# Altium schematic sheet Mataric1.SchDoc(Mataric1)
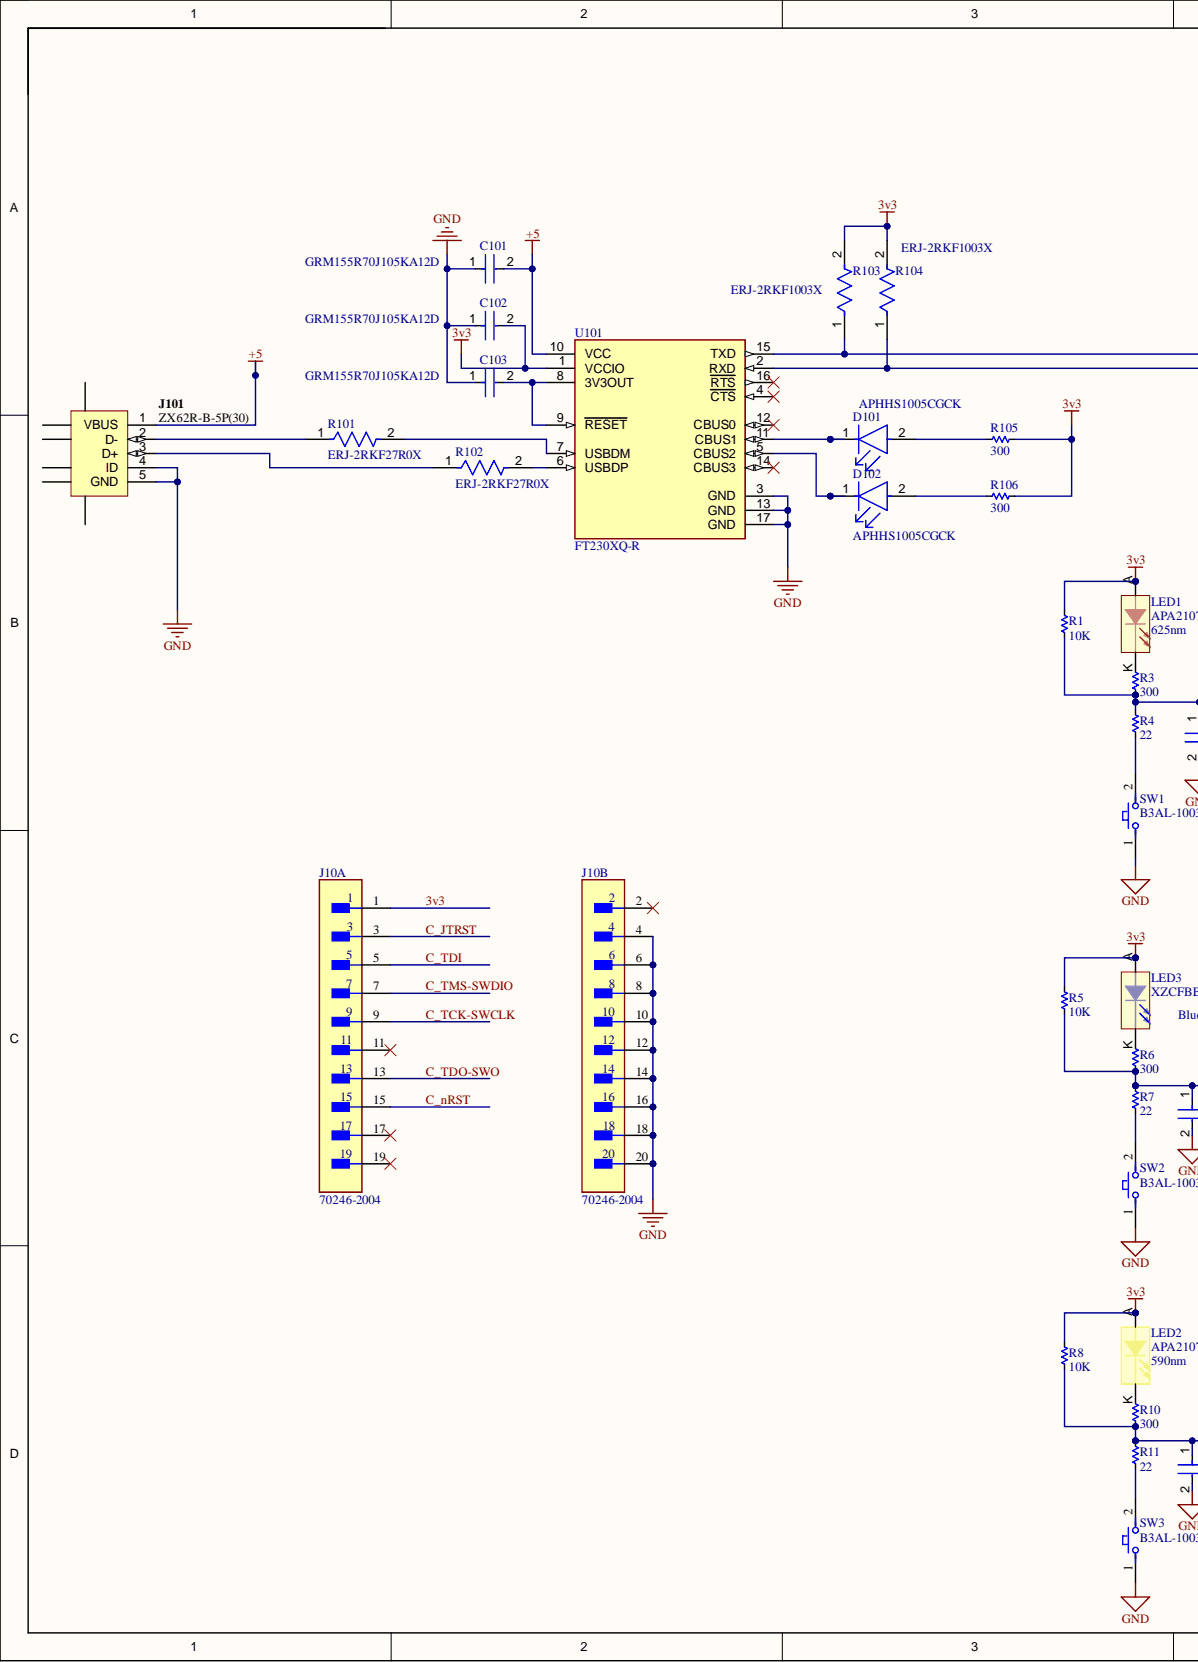
[diagram p0: Mataric1.SchDoc, left]
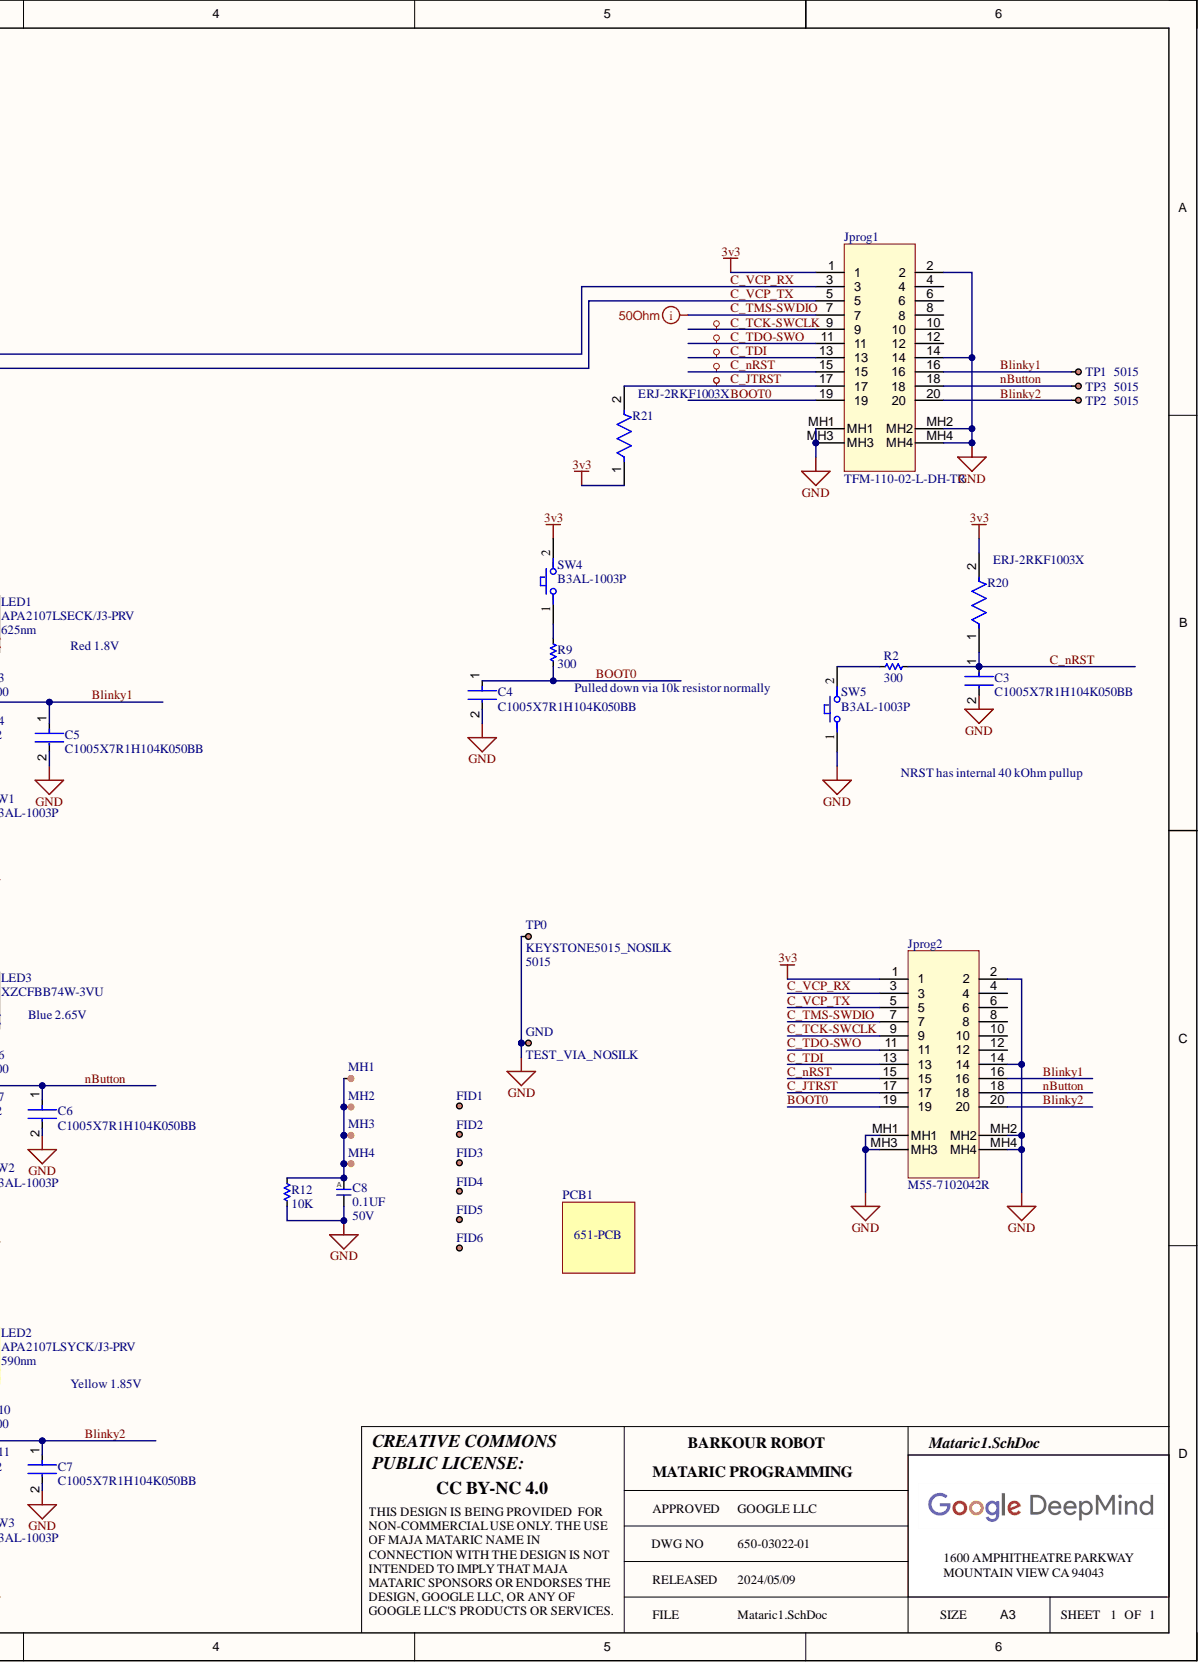
[diagram p1: Mataric1.SchDoc, right]

## Parts
C3  C1005X7R1H104K050BB  [FP-C1005-050-0_05-IPC_C]
C4  C1005X7R1H104K050BB  [FP-C1005-050-0_05-IPC_C]
C5  C1005X7R1H104K050BB  [FP-C1005-050-0_05-IPC_C]
C6  C1005X7R1H104K050BB  [FP-C1005-050-0_05-IPC_C]
C7  C1005X7R1H104K050BB  [FP-C1005-050-0_05-IPC_C]
C8  value 0.1UF  Voltage: 50V  [C0402]  (hidden comment: __Template_Cap_Auto)
C101  GRM155R70J105KA12D  [FP-GRM155-0_05-MFG]
C102  GRM155R70J105KA12D  [FP-GRM155-0_05-MFG]
C103  GRM155R70J105KA12D  [FP-GRM155-0_05-MFG]
D101  APHHS1005CGCK  [FP-APHHS1005CGCK-MFG]
D102  APHHS1005CGCK  [FP-APHHS1005CGCK-MFG]
FID1  FIDUCIAL  [FIDUCIAL_1MM]  (value not drawn)  (no BOM)
FID2  FIDUCIAL  [FIDUCIAL_1MM]  (value not drawn)  (no BOM)
FID3  FIDUCIAL  [FIDUCIAL_1MM]  (value not drawn)  (no BOM)
FID4  FIDUCIAL  [FIDUCIAL_1MM]  (value not drawn)  (no BOM)
FID5  FIDUCIAL  [FIDUCIAL_1MM]  (value not drawn)  (no BOM)
FID6  FIDUCIAL  [FIDUCIAL_1MM]  (value not drawn)  (no BOM)
GND  TEST_VIA_NOSILK  [TP_VIA_31pad_15drill_NS]  (no BOM)
J10  70246-2004  [FP-70246-2004-MFG]  (parts A,B)
J101  ZX62R-B-5P(30)  [HIRO-ZX62R-B-5P_V]
Jprog1  MFG P/N: TFM-110-02-L-DH-TR  [SAMTEC_TFM-110-02-L-DH]
Jprog2  M55-7102042R  [CON_M55-7102042R]
LED1  value APA2107LSECK/J3-PRV  Color / Wavelength: 625nm  MFG P/N: APA2107LSECK/J3-PRV  [LED_APA2106CGCK]  (hidden comment: __Template_LED_RED_AK)
LED2  value APA2107LSYCK/J3-PRV  Color / Wavelength: 590nm  MFG P/N: APA2107LSYCK/J3-PRV  [LED_APA2106CGCK]  (hidden comment: __Template_LED_YELLOW_AK)
LED3  value XZCFBB74W-3VU  MFG P/N: XZCFBB74W-3VU  [LED_APA2106CGCK]  (hidden comment: __Template_LED_BLUE_AK)
MH1  MH  [MH_1.6MM]  (no BOM)
MH2  MH  [MH_1.6MM]  (no BOM)
MH3  MH  [MH_1.6MM]  (no BOM)
MH4  MH  [MH_1.6MM]  (no BOM)
PCB1  PCB  (mechanical)
R1  value 10K  [R0805]
R2  value 300  [R0603]
R3  value 300  [R0603]
R4  value 22  [R0805]
R5  value 10K  [R0805]
R6  value 300  [R0603]
R7  value 22  [R0805]
R8  value 10K  [R0805]
R9  value 300  [R0603]
R10  value 300  [R0603]
R11  value 22  [R0805]
R12  value 10K  [R0805]
R20  ERJ-2RKF1003X  [FP-ERJ2RK-IPC_C]
R21  ERJ-2RKF1003X  [FP-ERJ2RK-IPC_C]
R101  ERJ-2RKF27R0X  [FP-ERJ2R-IPC_B]
R102  ERJ-2RKF27R0X  [FP-ERJ2R-IPC_B]
R103  ERJ-2RKF1003X  [FP-ERJ2RK-IPC_C]
R104  ERJ-2RKF1003X  [FP-ERJ2RK-IPC_C]
R105  value 300  [R0603]
R106  value 300  [R0603]
SW1  B3AL-1003P  [FP-B3AL-1003P-MFG]
SW2  B3AL-1003P  [FP-B3AL-1003P-MFG]
SW3  B3AL-1003P  [FP-B3AL-1003P-MFG]
SW4  B3AL-1003P  [FP-B3AL-1003P-MFG]
SW5  B3AL-1003P  [FP-B3AL-1003P-MFG]
TP0  KEYSTONE5015_NOSILK  MFG P/N: 5015  [KEYSTONE_5015_NS]
TP1  KEYSTONE5015_NOSILK  MFG P/N: 5015  [KEYSTONE_5015_NS]
TP2  KEYSTONE5015_NOSILK  MFG P/N: 5015  [KEYSTONE_5015_NS]
TP3  KEYSTONE5015_NOSILK  MFG P/N: 5015  [KEYSTONE_5015_NS]
U101  FT230XQ-R  [FP-QFN-16-MFG]

## Nets
+5: C101.2 J101.1(VBUS) U101.10(VCC)
GND: C3.2 C4.2 C5.2 C6.2 C7.2 C8.2 C101.1 C102.1 C103.1 GND.1 J10.4 J10.6 J10.8 J10.10 J10.12 J10.14 J10.16 J10.18 J10.20 J101.4(ID) J101.5(GND) Jprog1.2 Jprog1.14 Jprog1.MH1 Jprog1.MH2 Jprog1.MH3 Jprog1.MH4 Jprog2.2 Jprog2.14 Jprog2.MH1 Jprog2.MH2 Jprog2.MH3 Jprog2.MH4 R12.1 SW1.1 SW2.1 SW3.1 SW5.1 TP0.1 U101.3(GND) U101.13(GND) U101.17(GND)
3v3: C102.2 J10.1 Jprog1.1 Jprog2.1 LED1.A LED2.A LED3.A R1.2 R5.2 R8.2 R20.2 R21.1 R103.2 R104.2 R105.1 R106.1 SW4.2 U101.1(VCCIO)
Blinky1: C5.1 Jprog1.16 Jprog2.16 R1.1 R3.1 R4.2 TP1.1
Blinky2: C7.1 Jprog1.20 Jprog2.20 R8.1 R10.1 R11.2 TP2.1
BOOT0: C4.1 Jprog1.19 Jprog2.19 R9.1
C_JTRST: J10.3 Jprog1.17 Jprog2.17 R21.2
C_nRST: C3.1 J10.15 Jprog1.15 Jprog2.15 R2.1 R20.1
C_TCK-SWCLK: J10.9 Jprog1.9 Jprog2.9
C_TDI: J10.5 Jprog1.13 Jprog2.13
C_TDO-SWO: J10.13 Jprog1.11 Jprog2.11
C_TMS-SWDIO: J10.7 Jprog1.7 Jprog2.7
C_VCP_RX: Jprog1.3 Jprog2.3 R103.1 U101.15(TXD)
C_VCP_TX: Jprog1.5 Jprog2.5 R104.1 U101.2(RXD)
nButton: C6.1 Jprog1.18 Jprog2.18 R5.1 R6.1 R7.2 TP3.1
NetC8_1: C8.1 MH1.1 MH2.1 MH3.1 MH4.1 R12.2
NetC103_2: C103.2 U101.8(3V3OUT) U101.9(RESET)
NetD101_1: D101.1 U101.11(CBUS1)
NetD101_2: D101.2 R105.2
NetD102_1: D102.1 U101.5(CBUS2)
NetD102_2: D102.2 R106.2
NetJ101_2: J101.2(D-) R101.1
NetJ101_3: J101.3(D+) R102.1
NetLED1_K: LED1.K R3.2
NetLED2_K: LED2.K R10.2
NetLED3_K: LED3.K R6.2
NetR2_2: R2.2 SW5.2
NetR4_1: R4.1 SW1.2
NetR7_1: R7.1 SW2.2
NetR9_2: R9.2 SW4.1
NetR11_1: R11.1 SW3.2
NetR101_2: R101.2 U101.7(USBDM)
NetR102_2: R102.2 U101.6(USBDP)

Not connected: J10 2 11 17 19; J101 6 7 8 9 10 11; Jprog1 4 6 8 10 12; Jprog2 4 6 8 10 12; U101 4(CTS) 12(CBUS0) 14(CBUS3) 16(RTS)

## Library data of the parts
C3-C7 = CMP-2000-05393-2: Multilayer Ceramic Capacitors 100nF ±10% 50V X7R SMD 0402; Capacitance: 100nF; Case Code (Imperial): 0402; Case Code (Metric): 1005; Case/Package: 0402; Component Kind: Standard; Construction: Flat; Depth: 0.5mm; Dielectric: X7R; Dielectric Material: Ceramic; Height: 0.5mm; Lead Free: Lead Free; Length: 1mm; Library Name: Altium Content Vault; Max Operating Temperature: 125°C; Min Operating Temperature: -55°C; Mount: Surface Mount; Package Quantity: 1; Packaging: Tape and Reel; Radiation Hardening: No; REACH SVHC: No SVHC; Resistor Type: Ceramic; RoHS Compliant: Yes; Series: C; Termination: SMD/SMT; Thickness: 0.022inch; Tolerance: 10%; Voltage: 50V; Voltage Rating: 50V; Voltage Rating (DC): 50V; Weight: 2.3E-05oz; Width: 0.02inch
C8 = 951: CAP, CER, X7R, 0.1uF, 50V, 10%, 0402, AUTO GRADE; COMMON: 150-00281-00; Database Table Name: Capacitors; EES: 150-04463-00; MFG: TDK; MFG P/N: CGA2B3X7R1H104K050BB; PROXY: Use ID #1723; Component Kind: Standard; ComponentLink1Description: Datasheet; Dielectric: X7R; Gecko: 150-00281-00; Height: 0.56mm T; ID: 951; Library Name: AltiumReleaseLibDB.DBLib; LOON: 150-00281-00; Package: 0402; Status: Released; Tolerance: 10%; XPN: 150-00281-00
C101-C103 = CMP-2008-04587-2: Chip Multilayer Ceramic Capacitors for General Purpose, 0402, 1.0uF, X7R, 15%, 10%, 6.3V; Capacitance: 1uF; Case Code (Imperial): 0402; Case Code (Metric): 1005; Case/Package: 0402; Component Kind: Standard; Depth: 0.5mm; Dielectric: X7R; Height: 0.5mm; Lead Free: Lead Free; Length: 1mm; Library Name: Altium Content Vault; Material: Ceramic; Max Operating Temperature: 125°C; Min Operating Temperature: -55°C; Mount: Surface Mount; Package Quantity: 10000; Packaging: Tape and Reel; Termination: SMD/SMT; Thickness: 0.022inch; Tolerance: 10%; Voltage Rating: 6.3V; Voltage Rating (DC): 6.3V; Weight: 0.000212oz; Width: 0.5mm
D101 D102 = CMP-44529-000044-1: LED GREEN CLEAR CHIP SMD; Dominant Wavelength: 570nm; Forward Current: 20mA; Forward Voltage: 2.1V; Luminous Intensity: 50mcd; Number of LEDs: 1; Viewing Angle: 120°; Case Code (Imperial): 0402; Case Code (Metric): 1005; Case/Package: 0402; Color: Green; Component Kind: Standard; Height: 0.6mm; Illumination Color: Green; Lead Free: Lead Free; Length: 1mm; Lens Color: Clear; Lens Style: Clear; Library Name: Altium Content Vault; Max Operating Temperature: 85°C; Min Operating Temperature: -40°C; Mount: Surface Mount; Number of Pins: 2; Package Quantity: 2000; Packaging: Tape and Reel; Test Current: 20mA; Wavelength: 570nm; Width: 0.5mm
FID1-FID6 = 93: FIDUCIAL 1MM COPPER CIRCLE 3MM MASK PULLBACK; Database Table Name: Miscellaneous; MFG: _PCB Feature; ID: 93; Library Name: AltiumReleaseLibDB.DBLib; Status: In Development
GND = 171: TEST VIA, NO SILKSCREEN, 15 MIL DRILL, 31 MIL PAD; Database Table Name: Miscellaneous; MFG: _PCB Feature; Category: Testpoint vias; ID: 171; Library Name: AltiumReleaseLibDB.DBLib; Status: Released
J10 = CMP-04776-000337-1: CONN HEADER VERT 20POS 2.54MM; Current Rating: 2.5A; Gender: Male; Glow Wire Compliant: Non-compliant; Max Voltage Rating (DC): 250V; Number of Circuits: 20; Component Kind: Standard; Contact Material: Gold; Contact Plating: Gold; ELV: Compliant; Flammability Rating: UL94 V-0; Library Name: Altium Content Vault; Max Operating Temperature: 120°C; Min Operating Temperature: -55°C; Mount: Through Hole; Number of Contacts: 20; Number of Positions: 20; Number of Rows: 2; Orientation: Vertical; Package Quantity: 720; Packaging: Tray; Pitch: 2.54mm; Radiation Hardening: No; Series: 70246; Termination: Solder; Voltage Rating: 250V; Voltage Rating (DC): 250V
J101 = CMP-0031-00001-1: Connector; USBMicro-B,Reverse type, SMT; 5 Position; Right Angle; Component Kind: Standard; ComponentLink1Description: Manufacturer URL; ComponentLink1URL: http://www.hirose.com/â€Ž; ComponentLink2Description: Datasheet; ComponentLink2URL: http://www.hirose.co.jp/cataloge_hp/e24200011.pdf; DatasheetVersion: 08/2010; Library Name: Altium Content Vault; Mounting Technology: Surface Mount; Operating Temperature: -30 to 85 degC; PackageDescription: 5-Pin USB Micro-B R/A Connector; PackageReference: ZX62R-B-5P; PartNumber: ZX62R-B-5P(30)
Jprog1 = 1204: CONN, HDR, SHRD, RA, 2x10, 1.27MM P, 6.02MM h, GOLD, TH; Connector Type: Header; Current Rating: 2.9A; Database Table Name: Connectors; EES: 210-04980-00; MFG: Samtec Inc; Number of Positions: 20; Sub Assy Qty 2: 0; Voltage, Rated: 275VAC; Component Kind: Standard; ComponentLink1Description: Datasheet; ComponentLink1URL: http://suddendocs.samtec.com/prints/tfm-1xx-xx-x-dh-xx-mkt.pdf; Gender: Header; Height: 6.02mm; HelpURL: https://www.digikey.com/en/products/detail/samtec-inc/TFM-110-02-L-DH-TR/6694026; ID: 1204; Library Name: AltiumReleaseLibDB.DBLib; Mounting Type: Surface Mount; Number of Rows: 2; Operating Temperature: -55°C ~ 125°C; Orientation: Right Angle; Pitch: 1.27mm; RoHS Compliant: Yes; Row Spacing: 1.27mm; Status: Released; Sub Assy Qty 3: 0; Sub Assy Qty 4: 0; Supplier 1: Digi-Key; Supplier Part Number 1: SAM14687TR-ND
Jprog2 = 1204: CONN HEADER SMD R/A 20POS 1.27MM; Database Table Name: Connectors; MFG: Harwin Inc.; Component Kind: Standard; ComponentLink1URL: https://www.digikey.com/en/products/detail/harwin-inc/M55-7102042R/8537613?s=N4IgTCBcDaILIFYEFoDsBGADGTAWMASiALoC%2BQA; Library Name: AltiumReleaseLibDB.DBLib; MFG P/N: M55-7102042R; Status: Released; Supplier 1: Digi-Key; Supplier Part Number 1: 952-3893-1-ND; Value: M55-7102042R
LED1 = 280: LED, RED, 640NM, INDEPENDENT, CLEAR, RA, SMD-2; Database Table Name: Diodes; EES: 370-00593-00; MFG: Kingbright; Category: Diode; Component Kind: Standard; ComponentLink1Description: Datasheet; ComponentLink1URL: http://www.kingbrightusa.com/images/catalog/SPEC/APA2107LSECK-J3-PRV.pdf; Diode Type: LED; Height: 0.7mm; HelpURL: https://www.digikey.com/product-detail/en/kingbright/APA2107LSECK-J3-PRV/754-2094-1-ND/7319022; ID: 280; Library Name: AltiumReleaseLibDB.DBLib; Mounting Type: SMD; Package: 2-SMD, No Lead; RoHS Compliant: Yes; Status: Released; Supplier 1: Digi-Key; Supplier Part Number 1: 754-2094-1-ND
LED2 = 279: LED, YELLOW, 590NM, CLEAR, RA, SMD-2; Database Table Name: Diodes; EES: 370-00592-00; MFG: Kingbright; Voltage – Forward (VF) (MAX) @ IF: 1.85V; Category: Diode; Component Kind: Standard; ComponentLink1Description: Datasheet; ComponentLink1URL: http://www.kingbrightusa.com/images/catalog/SPEC/APA2107LSYCK-J3-PRV.pdf; Diode Type: LED; Height: 0.7mm; HelpURL: https://www.digikey.com/product-detail/en/kingbright/APA2107LSYCK-J3-PRV/754-1922-1-ND/5177452; ID: 279; Library Name: AltiumReleaseLibDB.DBLib; Mounting Type: SMD; Package: 2-SMD, No Lead; RoHS Compliant: Yes; Status: Released; Supplier 1: Digi-Key; Supplier Part Number 1: 754-1922-1-ND
LED3 = 281: LED, BLUE, 465NM, INDEPENDENT, CLEAR, RA, SMD-2; Color / Wavelength: 470nm; Database Table Name: Diodes; EES: 370-00594-00; MFG: SunLED; Category: Diode; Component Kind: Standard; ComponentLink1Description: Datasheet; ComponentLink1URL: https://www.sunledusa.com/products/spec/XZCFBB74W-3VU.pdf; Diode Type: LED; Height: 0.7mm; HelpURL: https://www.digikey.com/product-detail/en/sunled/XZCFBB74W-3VU/1497-XZCFBB74W-3VUCT-ND/10449786; ID: 281; Library Name: AltiumReleaseLibDB.DBLib; Mounting Type: SMD; Package: 2-SMD, No Lead; RoHS Compliant: Yes; Status: Released; Supplier 1: Digi-Key; Supplier Part Number 1: 1497-XZCFBB74W-3VUCT-ND
MH1-MH4 = 144: MOUNTING HOLE, M1.6, 4.0mm PAD; Database Table Name: Miscellaneous; ID: 144; Library Name: AltiumReleaseLibDB.DBLib; Status: Released
PCB1 = 110: Database Table Name: Miscellaneous; FindNumber: 010; Level: 1; Org: ORG - REP; Description: PCB; ID: 110; Library Name: AltiumReleaseLibDB.DBLib; ProductLine: Proxy; Status: In Development; Type: PCB
R1 R5 R8 R12 = 4154: RES, 10K, 1%, 1/8W, 0805; COMMON: 470-07506-00; Database Table Name: Resistors; EES: 470-17984-00; Gecko: 470-17702-00; MFG: YAGEO; MFG P/N: RC0805FR-0710KL; PROXY: 470-16861-00; XPN: XS-10018162; Component Kind: Standard; Height: 0.60mm; ID: 4154; Library Name: AltiumReleaseLibDB.DBLib; LOON: 470-07506-00; Package: 0805; Power: 1/8W; Status: Released; Supplier 1: Digi-Key; Supplier Part Number 1: 311-10.0KCRCT-ND; Tolerance: 1%
R2 R3 R6 R9 R10 R105 R106 = 2806: Resistor, 300 Ohms, 0603, 0.1W, ±1%; COMMON: 470-06251-00; Database Table Name: Resistors; EES: 470-17796-00; MFG: YAGEO; MFG P/N: RC0603FR-07300RL; Power: 1/10W; XPN: XS-10017062; Component Kind: Standard; Gecko: 470-06251-00; Height: 0.55mm; ID: 2806; Library Name: AltiumReleaseLibDB.DBLib; LOON: 470-06251-00; Package: 0603; Status: Released; Supplier 1: Digi-Key; Supplier Part Number 1: 311-300HRCT-ND; Tolerance: 1%
R4 R7 R11 = 1785: Resistor, 22.0 Ohms, 0805, 0.125W, ±1%; COMMON: 470-05283-00; Database Table Name: Resistors; EES: 470-17982-00; MFG: YAGEO; MFG P/N: RC0805FR-0722RL; Power: 1/8W; XPN: XS-10016192; Component Kind: Standard; Gecko: 470-05283-00; Height: 0.60mm; ID: 1785; Library Name: AltiumReleaseLibDB.DBLib; LOON: 470-05283-00; Package: 0805; Status: Released; Supplier 1: Digi-Key; Supplier Part Number 1: 311-22.0CRCT-ND; Tolerance: 1%
R20 R21 R103 R104 = CMP-2002-00608-4: RES SMD 100K OHM 1% 1/10W 0402; Max Power Dissipation: 100mW; Resistance: 100kR; Temperature Coefficient: 100ppm/°C; Voltage Rating: 50V; Case Code (Imperial): 0402; Case Code (Metric): 1005; Case/Package: 0402; Component Kind: Standard; Composition: Thick Film; Contact Plating: Tin; Depth: 0.5mm; Features: Automotive AEC-Q200; Height: 0.35mm; Lead Free: Lead Free; Length: 1mm; Library Name: Altium Content Vault; Max Operating Temperature: 155°C; Military Standard: Not; Min Operating Temperature: -55°C; Mount: Surface Mount; Number of Pins: 2; Number of Terminations: 2; Package Quantity: 1; Packaging: Tape and Reel; Power Rating: 100mW; Radiation Hardening: No; REACH SVHC: No SVHC; Termination: SMD/SMT; Tolerance: 1%; Voltage Rating (DC): 50V; Width: 0.5mm
R101 R102 = CMP-2002-00830-2: RES SMD 27 OHM 1% 1/10W 0402; Max Power Dissipation: 100mW; Resistance: 27R; Temperature Coefficient: 100ppm/°C; Voltage Rating: 50V; Case Code (Imperial): 0402; Case Code (Metric): 1005; Case/Package: 0402; Component Kind: Standard; Construction: Rectangular; Contact Plating: Tin; Depth: 0.5mm; Features: Automotive AEC-Q200; Height: 0.35mm; Lead Free: Lead Free; Length: 1mm; Library Name: Altium Content Vault; Max Operating Temperature: 155°C; Military Standard: Not; Min Operating Temperature: -55°C; Mount: Surface Mount; Number of Pins: 2; Number of Terminations: 2; Package Quantity: 1; Packaging: Tape and Reel; Power Rating: 100mW; Radiation Hardening: No; REACH SVHC: No SVHC; Resistance Tolerance: 1%; Resistor Type: Thick Film; RoHS Compliant: Yes; Series: ERJ; Technology: THICK FILM; Termination: SMD/SMT; Tolerance: 1%; Voltage Rating (DC): 50V; Weight: 2.8E-05oz; Width: 0.5mm
SW1-SW5 = CMP-08834-000018-1: SWITCH TACTILE SPST-NO 0.05A 16V; Actuator Color: White; Contact Current Rating: 50mA; Contact Resistance: 100mR; Electrical Life: 1000000Cycles; Illumination Type: Non-Illuminated; Operating Force: 3.5N; Switch Function: Off-Mom; Switch Travel: 1.3mm; Throw Configuration: SPST; Component Kind: Standard; Contact Plating: Silver; Current Rating: 50mA; Depth: 6.6mm; Length: 6.9mm; Library Name: Altium Content Vault; Max Current Rating: 50mA; Max Operating Temperature: 90°C; Max Voltage Rating (DC): 16V; Mechanical Life: 1000000Cycles; Min Operating Temperature: -40°C; Mount: Surface Mount; Orientation: Straight; Package Quantity: 1; Packaging: Tape and Reel; Radiation Hardening: No; RoHS Compliant: Yes; Series: B3AL; Termination: SMD/SMT; Voltage Rating (DC): 16V; Weight: 0.18g
TP0-TP3 = 176: HW, TEST POINT, NO SILKSCREEN, PC MINIATURE, 0.25mm T, 1.52mm HEIGHT, SILVER PLATING, SMT; COMMON: 250-00207-00; Database Table Name: Miscellaneous; EES: 250-08552-00; EPIC: 250-08204-00; KOI: 250-07313-00; MFG: Keystone Electronics Corp.; PROXY: 250-04113-00; XPN: XS-10008125; Category: Test and Measurement; Component Kind: Standard; ComponentLink1Description: Datasheet; ComponentLink1URL: http://keyelco.com/userAssets/file/M65p55.pdf; Gecko: 250-00207-00; Height: 1.65mm; HelpURL: https://www.digikey.com/product-detail/en/keystone-electronics/5015/36-5015CT-ND/278886; ID: 176; Library Name: AltiumReleaseLibDB.DBLib; LOON: 250-00207-00; Mounting Type: SMD; Status: In Development; Supplier 1: Digi-Key; Supplier Part Number 1: 36-5015CT-ND
U101 = CMP-0248-00010-3: IC USB SERIAL BASIC UART 16QFN; Data Rate: 12Mbps; Max Supply Voltage: 5.5V; Min Supply Voltage: 2.97V; Number of Channels: 1; Operating Supply Current: 8mA; Operating Supply Voltage: 5V; Case/Package: QFN; Component Kind: Standard; Height: 0.75mm; Interface: USB; Lead Free: Lead Free; Length: 4mm; Library Name: Altium Content Vault; Max Operating Temperature: 85°C; Min Operating Temperature: -40°C; Mount: Surface Mount; Number of Pins: 16; Package Quantity: 5000; Packaging: Tape and Reel; Weight: 0.002014oz; Width: 4mm
